# Altium schematic sheet final-v1.SchDoc
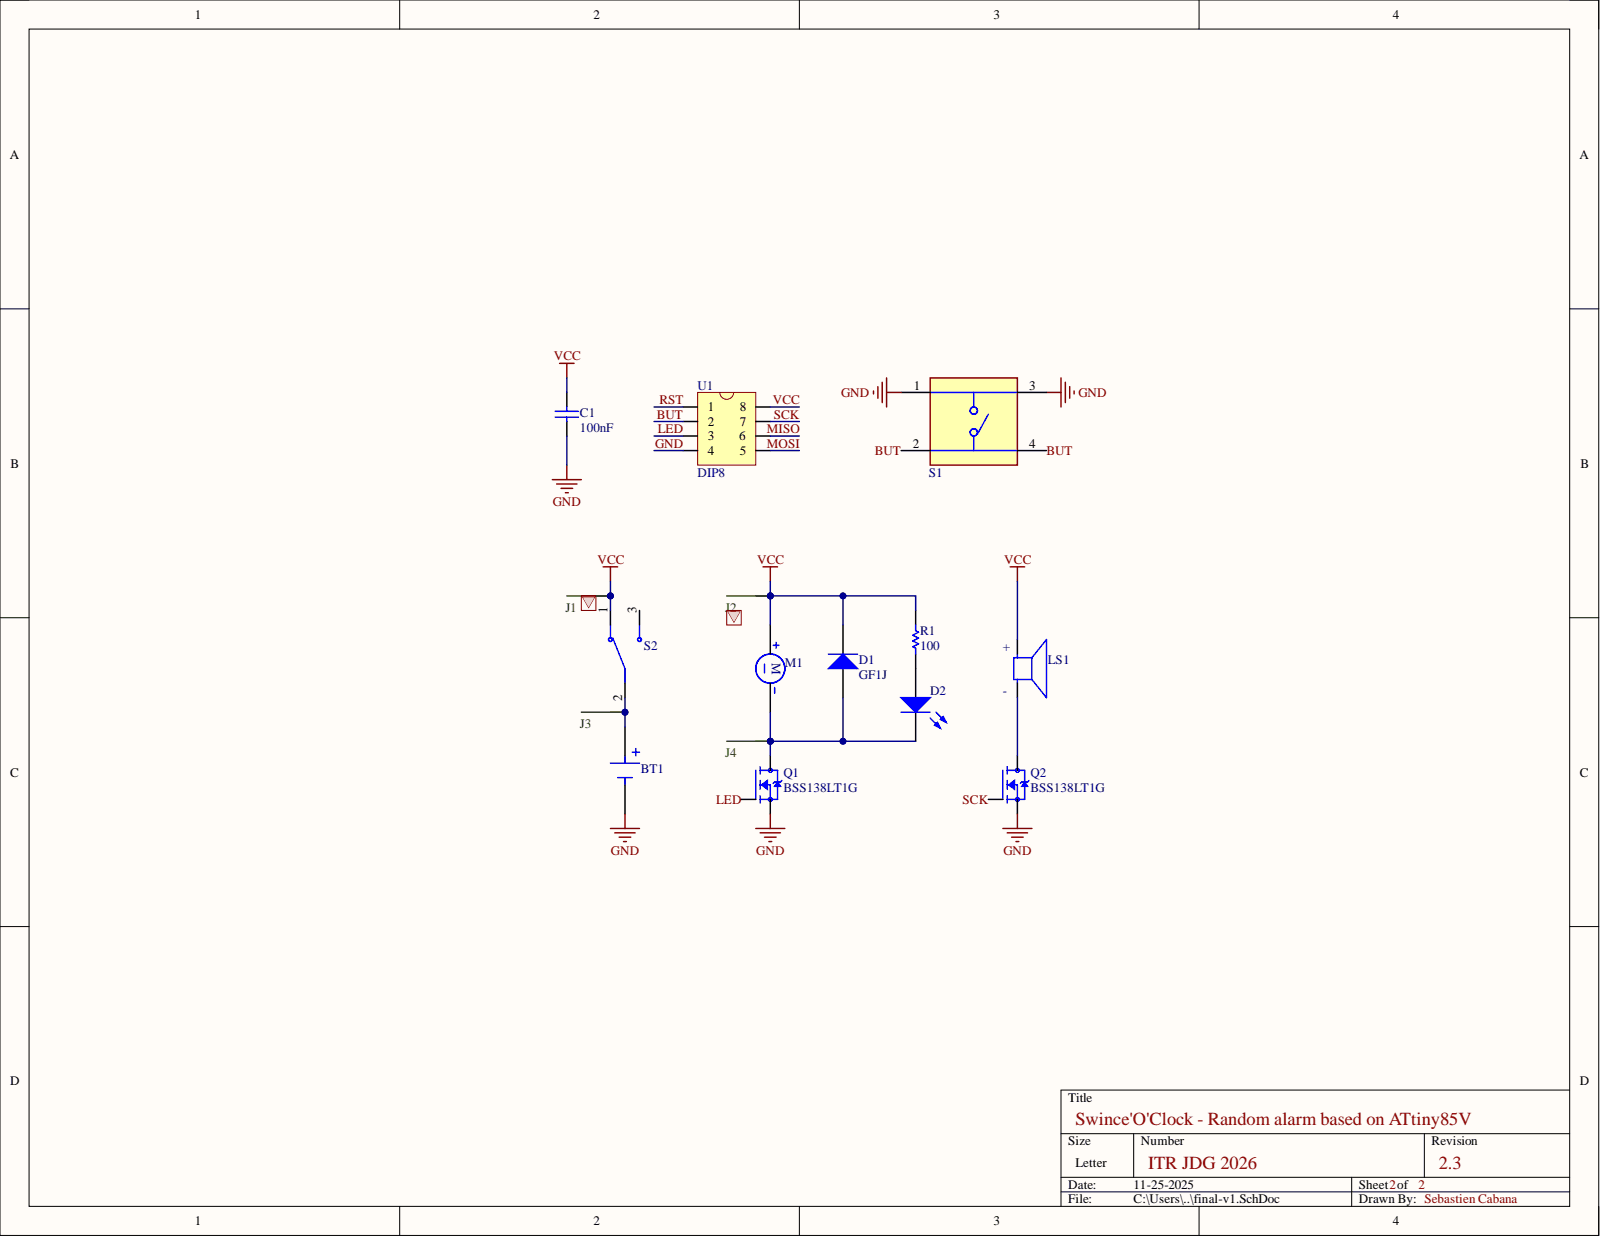
[diagram p0: final-v1.SchDoc]

## Parts
BT1  BH123A  [BAT_BH123A]  (value not drawn)
C1  value 100nF  [SMD-0805]  (hidden comment: Cap-SM)
D1  GF1J  [DIOM5426X300N]
D2  LED  [LED_GREEN]  (value not drawn)
J1  Jumper-EXT-22AWG  [Jumper-EXT-22AWG]  (value not drawn)
J2  Jumper-EXT-22AWG  [Jumper-EXT-22AWG]  (value not drawn)
J3  Jumper-EXT-22AWG  [Jumper-EXT-22AWG]  (value not drawn)
J4  Jumper-EXT-22AWG  [Jumper-EXT-22AWG]  (value not drawn)
LS1  SMTB-0927-TW-R  [SMTB-0927-TW-R]  (value not drawn)
M1  HD-EMB1205-2-LW30-R  [HD-EMB1205-2-LW30-R]  (value not drawn)
Q1  BSS138LT1G  [SOT-23-3]
Q2  BSS138LT1G  [SOT-23-3]
R1  value 100  [SMD-0805]  (hidden comment: Res-SM)
S1  Pushbutton  [SW_TS04-66-70-BK-260-SMT]  (value not drawn)
S2  Switch  [SW_SLW-195557-5A-N-D]  (value not drawn)
U1  DIP8  [DIP-8-Socket]

## Nets
BUT: S1.2 S1.4 U1.2
GND: BT1.N C1.1 Q1.2 Q2.2 S1.1 S1.3 U1.4
LED: Q1.1 U1.3
MISO: U1.6
MOSI: U1.5
RST: U1.1
SCK: Q2.1 U1.7
VCC: C1.2 D1.2 J1.1 J2.1 LS1.P M1.P R1.2 S2.1 U1.8
NetBT1_P: BT1.P J3.1 S2.2
NetD1_1: D1.1 D2.2 J4.1 M1.N Q1.3
NetD2_1: D2.1 R1.1
NetLS1_N: LS1.N Q2.3

Not connected: S2 3

## Library data of the parts
BT1 = BH123A: Battery Holder (Open) CR123A 1 Cell PC Pin; MF: MPD; Check_prices: https://www.snapeda.com/parts/BH123A/MPD/view-part/?ref=eda; Component Kind: Standard; ComponentLink1Description: Manufacturer Link; ComponentLink1URL: https://www.digikey.ca/en/products/detail/mpd-memory-protection-devices/BH123A/2817712; ComponentLink2Description: Datasheet; ComponentLink2URL: https://www.memoryprotectiondevices.com/datasheets/BH123A/BH123A-datasheet.pdf; DigiKey Part Number: BH123A-ND; MountingType: Through Hole; MP: BH123A; Package: None; SnapEDA_Link: https://www.snapeda.com/parts/BH123A/MPD/view-part/?ref=snap; Supplier 1: DigiKey; Supplier Part Number 1: BH123A-ND; Library Name: CABS_2025.IntLib
C1 = Cap-SM: Capacitor - Surface Mount standard pads; Component Kind: Standard; MountingType: Surface Mount; Signal Integrity: Cap; Simulation: CAP; Library Name: CABS_2025.IntLib
D1 = GF1J: Diode 600 V 1A Surface Mount DO-214AC (SMA); Component Kind: Standard; ComponentLink1Description: Manufacturer; ComponentLink1URL: https://www.digikey.ca/en/products/detail/onsemi/GF1J/1049309; ComponentLink2Description: Datasheet; ComponentLink2URL: https://www.onsemi.com/pdf/datasheet/gf1m-d.pdf; DigiKey Part Number: GF1JFSTR-ND; MountingType: Surface Mount; PackageReference: DO-214AC; Simulation: gf1g; Library Name: FIP-Custom-Lib.IntLib
D2 = LED: Typical LED; Component Kind: Standard; MountingType: Through Hole; Simulation: LED2; Library Name: CABS_2025.IntLib
J1-J4 = Jumper-EXT-22AWG: 1 Point Jumper Wire 22 AWG ; For External Connections; Component Kind: Standard; ComponentLink1Description: Manufacturer Link; ComponentLink1URL: https://www.digikey.ca/en/products/detail/3m/923345-05-C/12201; ComponentLink2Description: Datasheet; ComponentLink2URL: https://mm.digikey.com/Volume0/opasdata/d220001/medias/docus/2575/Solderless%20Breadboard%20Acces.pdf; DigiKey Part Number: 923345-05-C; MountingType: Through Hole; Supplier 1: DigiKey; Supplier 2: Mouser; Supplier Part Number 1: 923345-05-ND; Supplier Part Number 2: 517-923345-05; Library Name: CABS_2025.IntLib
LS1 = SMTB-0927-TW-R: Buzzers Transducer, Externally Driven Electromechanical/Magnetic 3 V 80mA 2.7kHz 95dB @ 3V; MF: PUI Audio; Check_prices: https://www.snapeda.com/parts/SMTB-0927-TW-R/PUI+Audio/view-part/?ref=eda; Component Kind: Standard; ComponentLink1Description: Datasheet; ComponentLink1URL: https://puiaudio.com/file/specs-SMTB-0927-TW-R.pdf; ComponentLink2Description: Manufacturer Link; ComponentLink2URL: https://www.digikey.ca/en/products/detail/pui-audio-inc/SMTB-0927-TW-R/13165907; Digikey Part Number: 668-SMTB-0927-TW-RCT-ND; MountingType: Surface Mount; MP: SMTB-0927-TW-R; Package: Package; SnapEDA_Link: https://www.snapeda.com/parts/SMTB-0927-TW-R/PUI+Audio/view-part/?ref=snap; Supplier 1: DigiKey; Supplier 2: DigiKey; Supplier 3: DigiKey; Supplier 4: Mouser; Supplier Part Number 1: 668-SMTB-0927-TW-RCT-ND; Supplier Part Number 2: 668-SMTB-0927-TW-RDKR-ND; Supplier Part Number 3: 668-SMTB-0927-TW-RTR-ND; Supplier Part Number 4: 665-SMTB0927TWR; Library Name: CABS_2025.IntLib
M1 = HD-EMB1205-2-LW30-R: DC Motor Vibration, ERM (Haptic) 13000 RPM 3VDC; MF: {'manufacturer': u'PUI Audio, Inc.'}; Availability: Unavailable; Component Kind: Standard; ComponentLink1Description: Datasheet; ComponentLink1URL: https://puiaudio.com/file/specs-HD-EMB1205-2-LW30-R.pdf; ComponentLink2Description: Manufacturer Link; ComponentLink2URL: https://www.digikey.ca/en/products/detail/pui-audio-inc/HD-EMB1205-2-LW30-R/16522084; Digikey Part Number: 668-HD-EMB1205-2-LW30-R-ND; MountingType: Through Hole; MP: HD-EMB1205-2-LW30-R; Package: None; Price: None; Supplier 1: DigiKey; Supplier 2: Mouser; Supplier Part Number 1: 668-HD-EMB1205-2-LW30-R-ND; Supplier Part Number 2: 665-HDEMB12052LW30R; Library Name: CABS_2025.IntLib
Q1 Q2 = BSS138LT1G: N-Channel 50 V 200mA (Ta) 225mW (Ta) Surface Mount SOT-23-3 (TO-236); MF: onsemi; Check_prices: https://www.snapeda.com/parts/BSS138LT1G/Onsemi/view-part/?ref=eda; Component Kind: Standard; ComponentLink1Description: Manufacturer Link; ComponentLink1URL: https://www.digikey.ca/en/products/detail/onsemi/BSS138LT1G/918376?s=N4IgTCBcDaIEIGUEEYDMAOAMgFWQcRAF0BfIA; ComponentLink2Description: Datasheet; ComponentLink2URL: https://www.onsemi.com/pdf/datasheet/bss138lt1-d.pdf; Digikey Part Number: BSS138LT1GOSCT-ND; MountingType: Surface Mount; MP: BSS138LT1G; Package: SOT-23-3 ON Semiconductor; SnapEDA_Link: https://www.snapeda.com/parts/BSS138LT1G/Onsemi/view-part/?ref=snap; Supplier 1: DigiKey; Supplier 2: DigiKey; Supplier 3: DigiKey; Supplier 4: Mouser; Supplier Part Number 1: BSS138LT1GOSCT-ND; Supplier Part Number 2: BSS138LT1GOSDKR-ND; Supplier Part Number 3: BSS138LT1GOSTR-ND; Supplier Part Number 4: 863-BSS138LT1G; Library Name: CABS_2025.IntLib
R1 = Res-SM: Resistor - Surface Mount standard pads; Component Kind: Standard; LatestRevisionDate: 17-Jul-2002; LatestRevisionNote: Re-released for DXP Platform.; MountingType: Surface Mount; PackageDescription: Axial Device, Thru-Hole; 2 Leads; 0.3 in Pin Spacing; PackageReference: AXIAL-0.3; Published: 8-Jun-2000; Signal Integrity: Res; Simulation: RESISTOR; Library Name: CABS_2025.IntLib; Publisher: Altium Limited
S1 = TS04-66-70-BK-260-SMT: 6 x 6 mm, 7 mm Actuator Height, 260 gf, Black, Surface Mount, SPST, Tactile Switch; MF: Same Sky; Check_prices: https://www.snapeda.com/parts/TS04-66-70-BK-260-SMT/Same+Sky/view-part/?ref=eda; Component Kind: Standard; DigiKey Part Number: 2223-TS04-66-70-BK-260-SMT-ND; Library Name: JDG2026.SchLib; MountingType: Surface Mount; MP: TS04-66-70-BK-260-SMT; Package: None; SnapEDA_Link: https://www.snapeda.com/parts/TS04-66-70-BK-260-SMT/Same+Sky/view-part/?ref=snap; Supplier 1: Digikey; Supplier 2: Mouser; Supplier Part Number 1: 2223-TS04-66-70-BK-260-SMT-ND; Supplier Part Number 2: 179-TS046670BK260SMT
S2 = SLW-195557-5A-N-D: Slide Switch SPDT Panel Mount; Availability: Unavailable; Component Kind: Standard; ComponentLink1Description: Datasheet; ComponentLink1URL: https://www.sameskydevices.com/product/resource/slw-195557-5a-n-d.pdf; ComponentLink2Description: Manufacturer Link; ComponentLink2URL: https://www.digikey.ca/en/products/detail/same-sky-formerly-cui-devices/SLW-195557-5A-N-D/24399230; DigiKey Part Number: 2223-SLW-195557-5A-N-D-ND; MountingType: Panel Mount; MP: SLW-195557-5A-N-D; Package: None; Price: None; Supplier 1: DigiKey; Supplier 2: Mouser; Supplier Part Number 1: 2223-SLW-195557-5A-N-D-ND; Supplier Part Number 2: 179-SLW1955575AND; Library Name: CABS_2025.IntLib
U1 = DIP-8-Socket: 8 (2 x 4) Pos DIP, 0.3" (7.62mm) Row Spacing Socket Tin Through Hole; Component Kind: Standard; ComponentLink1Description: Datasheet; ComponentLink1URL: https://www.assmann-wsw.com/uploads/datasheets/ASS_0810_CO.pdf; ComponentLink2Description: Manufacturer Link; ComponentLink2URL: https://www.digikey.ca/en/products/detail/assmann-wsw-components/A-08-LC-TT/821740; DigiKey Part Number: AE9986-ND; MountingType: Through Hole; Supplier 1: DigiKey; Supplier Part Number 1: AE9986-ND; Library Name: CABS_2025.IntLib
